# Altium schematic sheet Microcontroller.SchDoc
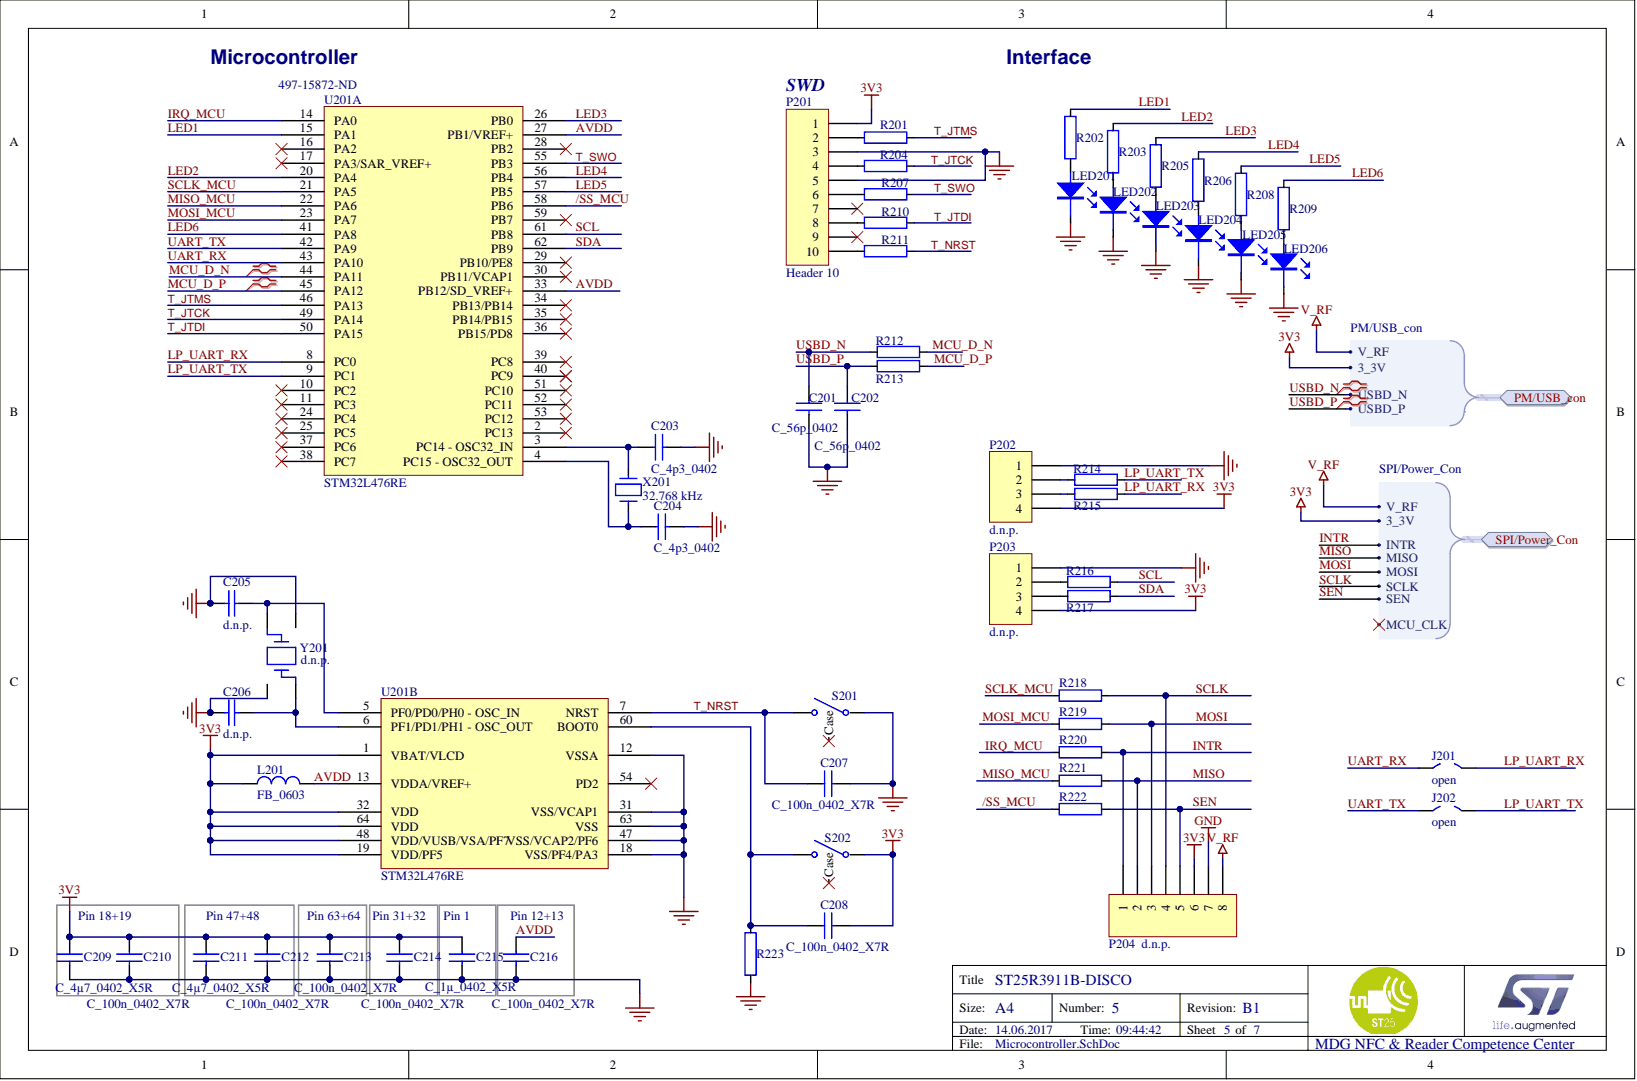
[diagram p0: Microcontroller.SchDoc]

## Parts
C201  C_56p_0402  [C0402]
C202  C_56p_0402  [C0402]
C203  C_4p3_0402  [C0402]
C204  C_4p3_0402  [C0402]
C205  d.n.p.  [C0603]
C206  d.n.p.  [C0603]
C207  C_100n_0402_X7R  [C0402]
C208  C_100n_0402_X7R  [C0402]
C209  C_4µ7_0402_X5R  [C0402]
C210  C_100n_0402_X7R  [C0402]
C211  C_4µ7_0402_X5R  [C0402]
C212  C_100n_0402_X7R  [C0402]
C213  C_100n_0402_X7R  [C0402]
C214  C_100n_0402_X7R  [C0402]
C215  C_1µ_0402_X5R  [C0402]
C216  C_100n_0402_X7R  [C0402]
J201  open  [JP2_SMD_open]
J202  open  [JP2_SMD_open]
L201  FB_0603  [L0603]
LED201  Blue  [D0603]  (value not drawn)
LED202  Blue  [D0603]  (value not drawn)
LED203  Blue  [D0603]  (value not drawn)
LED204  Blue  [D0603]  (value not drawn)
LED205  Blue  [D0603]  (value not drawn)
LED206  Blue  [D0603]  (value not drawn)
P201  Header 10  [Samtec-Strip5x2]
P202  d.n.p.  [HDR1X4]
P203  d.n.p.  [HDR1X4]
P204  d.n.p.  [HDR1X8]
R201  220R  [R0402]  (value not drawn)
R202  680R  [R0402]  (value not drawn)
R203  680R  [R0402]  (value not drawn)
R204  220R  [R0402]  (value not drawn)
R205  680R  [R0402]  (value not drawn)
R206  680R  [R0402]  (value not drawn)
R207  220R  [R0402]  (value not drawn)
R208  680R  [R0402]  (value not drawn)
R209  680R  [R0402]  (value not drawn)
R210  220R  [R0402]  (value not drawn)
R211  220R  [R0402]  (value not drawn)
R212  27R  [R0402]  (value not drawn)
R213  27R  [R0402]  (value not drawn)
R214  220R  [R0402]  (value not drawn)
R215  220R  [R0402]  (value not drawn)
R216  220R  [R0402]  (value not drawn)
R217  220R  [R0402]  (value not drawn)
R218  0R  [R0402]  (value not drawn)
R219  0R  [R0402]  (value not drawn)
R220  0R  [R0402]  (value not drawn)
R221  0R  [R0402]  (value not drawn)
R222  0R  [R0402]  (value not drawn)
R223  10k  [R0402]  (value not drawn)
S201  (no value)  [SW_SPST_KMR211G]
S202  (no value)  [SW_SPST_KMR211G]
U201  STM32L476RE  Supplier Part Number 1: 497-15872-ND  [LQFP64_slim]  (parts A,B)
X201  32.768 kHz  [NX3215SA]
Y201  d.n.p.  [XRCGB_F_MN]

## Ports
PM/USB_con, PM/USB_con.3_3V, PM/USB_con.USBD_N, PM/USB_con.USBD_P, PM/USB_con.V_RF, SPI/Power_Con, SPI/Power_Con.3_3V, SPI/Power_Con.INTR, SPI/Power_Con.MCU_CLK, SPI/Power_Con.MISO, SPI/Power_Con.MOSI, SPI/Power_Con.SCLK, SPI/Power_Con.SEN, SPI/Power_Con.V_RF

## Nets
GND: C201.2 C202.2 C203.2 C204.2 C205.2 C206.2 C207.2 C209.2 C210.2 C211.2 C212.2 C213.2 C214.2 C215.2 C216.2 LED201.2 LED202.2 LED203.2 LED204.2 LED205.2 LED206.2 P201.3 P201.5 P202.1 P203.1 P204.7 R223.1 S201.2 U201.12(VSSA) U201.18(VSS/PF4/PA3) U201.31(VSS/VCAP1) U201.47(VSS/VCAP2/PF6) U201.63(VSS) Y201.2 Y201.4
3V3: C208.2 C209.1 C210.1 C211.1 C212.1 C213.1 C214.1 C215.1 L201.2 P201.1 P202.4 P203.4 P204.6 S202.2 U201.1(VBAT/VLCD) U201.19(VDD/PF5) U201.32(VDD) U201.48(VDD/VUSB/VSA/PF7) U201.64(VDD) ; port PM/USB_con, port SPI/Power_Con
/SS_MCU: R222.2 U201.58(PB6)
AVDD: C216.1 L201.1 U201.13(VDDA/VREF+) U201.27(PB1/VREF+) U201.33(PB12/SD_VREF+)
INTR: P204.1 R220.1 ; port SPI/Power_Con
IRQ_MCU: R220.2 U201.14(PA0)
LED1: R202.2 U201.15(PA1)
LED2: R203.2 U201.20(PA4)
LED3: R205.2 U201.26(PB0)
LED4: R206.2 U201.56(PB4)
LED5: R208.2 U201.57(PB5)
LED6: R209.2 U201.41(PA8)
LP_UART_RX: J201.2 R215.1 U201.8(PC0)
LP_UART_TX: J202.2 R214.1 U201.9(PC1)
MCU_D_N: R212.1 U201.44(PA11)
MCU_D_P: R213.1 U201.45(PA12)
MISO: P204.2 R221.1 ; port SPI/Power_Con
MISO_MCU: R221.2 U201.22(PA6)
MOSI: P204.3 R219.1 ; port SPI/Power_Con
MOSI_MCU: R219.2 U201.23(PA7)
SCL: R216.1 U201.61(PB8)
SCLK: P204.4 R218.1 ; port SPI/Power_Con
SCLK_MCU: R218.2 U201.21(PA5)
SDA: R217.1 U201.62(PB9)
SEN: P204.5 R222.1 ; port SPI/Power_Con
T_JTCK: R204.1 U201.49(PA14)
T_JTDI: R210.1 U201.50(PA15)
T_JTMS: R201.1 U201.46(PA13)
T_NRST: C207.1 R211.1 S201.1 U201.7(NRST)
T_SWO: R207.1 U201.55(PB3)
UART_RX: J201.1 U201.43(PA10)
UART_TX: J202.1 U201.42(PA9)
USBD_N: C201.1 R212.2 ; port PM/USB_con
USBD_P: C202.1 R213.2 ; port PM/USB_con
V_RF: P204.8 ; port PM/USB_con, port SPI/Power_Con
NetC203_1: C203.1 U201.3(PC14_-_OSC32_IN) X201.2
NetC204_1: C204.1 U201.4 X201.1
NetC205_1: C205.1 U201.5 Y201.1
NetC206_1: C206.1 U201.6 Y201.3
NetC208_1: C208.1 R223.2 S202.1 U201.60(BOOT0)
NetLED201_1: LED201.1 R202.1
NetLED202_1: LED202.1 R203.1
NetLED203_1: LED203.1 R205.1
NetLED204_1: LED204.1 R206.1
NetLED205_1: LED205.1 R208.1
NetLED206_1: LED206.1 R209.1
NetP201_2: P201.2 R201.2
NetP201_4: P201.4 R204.2
NetP201_6: P201.6 R207.2
NetP201_8: P201.8 R210.2
NetP201_10: P201.10 R211.2
NetP202_2: P202.2 R214.2
NetP202_3: P202.3 R215.2
NetP203_2: P203.2 R216.2
NetP203_3: P203.3 R217.2
N00060: ; port SPI/Power_Con

Not connected: P201 7 9; S201 0; S202 0; U201 2(PC13) 10(PC2) 11(PC3) 16(PA2) 17(PA3/SAR_VREF+) 24(PC4) 25(PC5) 28(PB2) 29(PB10/PE8) 30(PB11/VCAP1) 34(PB13/PB14) 35(PB14/PB15) 36(PB15/PD8) 37(PC6) 38(PC7) 39(PC8) 40(PC9) 51(PC10) 52(PC11) 53(PC12) 54(PD2) 59(PB7)

## Library data of the parts
C201 C202 = C_56p_0402: 56p_COG; Manufacturer: MURATA; Manufacturer Part Number: GRM1555C1H560GA01D; 2nd Source: 56pF ±2% 50V  C0G, NP0 0402; Component Kind: Standard; Library Name: Schlib1.SchLib; Supplier 1: Digi-Key; Supplier Part Number 1: 490-6247-1-ND
C203 C204 = C_4p3_0402: 4p3_COG; Manufacturer: MURATA; Manufacturer Part Number: GRM0225C1E4R3BA03L; 2nd Source: 0402, 4.3PF 25V, C0G, 0.1pF; Component Kind: Standard; Library Name: Schlib1.SchLib; Supplier 1: Digi-Key; Supplier Part Number 1: 490-13573-1-ND
C205 C206 = CAP: Capacitor; Component Kind: Standard
C207 C208 C210 C212-C214 C216 = C_100n_0402_X7R: 100n_X7R; Manufacturer: MULTICOMP; Manufacturer Part Number: MC0402X104K100CT; 2nd Source: 0402, 0.1 µF, 10 V, ± 10%, X5R,; Component Kind: Standard; Library Name: Schlib1.SchLib; Supplier 1: Farnell; Supplier Part Number 1: 1759380
C209 C211 = C_4µ7_0402_X5R: 4u7_X5R; Manufacturer: TDK; Manufacturer Part Number: C1005X5R0J475K050BC; 2nd Source: 0402, 4.7 µF, 6.3 V, ± 10%, X5R; Component Kind: Standard; Library Name: Schlib1.SchLib; Supplier 2: Farnell; Supplier Part Number 2: 2346876
C215 = C_1µ_0402_X5R: 1u_X5R; Manufacturer: MURATA; Manufacturer Part Number: GRM155R61C105KA12D; 2nd Source: 0402, 1 µF, 16 V, ± 10%, X5R,; Component Kind: Standard; Library Name: Schlib1.SchLib; Supplier 1: Farnell; Supplier Part Number 1: 2362093
J201 J202 = solder_jumper_open: Component Kind: Net Tie; Library Name: Schlib1.SchLib
L201 = FB_0603: Manufacturer: MURATA; Manufacturer Part Number: BLM18EG471SN1D; Component Kind: Standard; Library Name: Schlib1.SchLib; Supplier 1: Farnell; Supplier Part Number 1: 1515722
LED201-LED206 = LED-0603-Blue: Manufacturer: Lite-On; Manufacturer Part Number: LTST-C190TBKT; Component Kind: Standard; Library Name: Schlib1.SchLib; Supplier 1: Digi-Key; Supplier Part Number 1: 160-1646-1-ND
P201 = Header 10: Samtec; Manufacturer Part Number: FTS-105-01-L-DV; Component Kind: Standard; Library Name: Schlib1.SchLib; Manufacturer: Samtec; Supplier 1: Farnell; Supplier Part Number 1: 1667729
P202 P203 = Header 4: Header, 4-Pin; Component Kind: Standard; LatestRevisionDate: 17-Jul-2002; LatestRevisionNote: Re-released for DXP Platform.; Library Name: Miscellaneous Connectors.IntLib; Signal Integrity: Connector; Publisher: Altium Limited
P204 = Header 8: Header, 8-Pin; Component Kind: Standard; LatestRevisionDate: 17-Jul-2002; LatestRevisionNote: Re-released for DXP Platform.; Library Name: Miscellaneous Connectors.IntLib; Signal Integrity: Connector; Publisher: Altium Limited
R201 R204 R207 R210 R211 R214-R217 = R0402_220R: 220R, 0402; Manufacturer: Yageo; Manufacturer Part Number: RC0402JR-07220RL; 2nd Source: 220 Ohm ±5% 0.063W, 1/16W Chip Resistor 0402; Component Kind: Standard; Library Name: Schlib1.SchLib; Supplier 1: Digi-Key; Supplier Part Number 1: 311-220JRTR-ND
R202 R203 R205 R206 R208 R209 = R0402_680R: 680R, 0402; Manufacturer: Yageo; Manufacturer Part Number: RC0402JR-07680RL; 2nd Source: 680 Ohm ±5% 0.063W, 1/16W Chip Resistor 0402; Component Kind: Standard; Library Name: Schlib1.SchLib; Supplier 1: Digi-Key; Supplier Part Number 1: 311-680JRTR-ND
R212 R213 = R0402_27R: 27R, 0402; Manufacturer: MULTICOMP; Manufacturer Part Number: MC00625W0402127R; 2nd Source: 27ohm, 50 V, 0402, >62.5 mW, ± 1%; Component Kind: Standard; Library Name: Schlib1.SchLib; Supplier 1: Farnell; Supplier Part Number 1: 1358000
R218-R222 = R0402_0R: 0R, 0402; Manufacturer: MULTICOMP; Manufacturer Part Number: MC00625W040210R; 2nd Source: 0 ohm, 50 V, 0402, >62.5 mW; Component Kind: Standard; Library Name: Schlib1.SchLib; Supplier 1: Farnell; Supplier Part Number 1: 1357983
R223 = R0402_10k: 10k, 0402; Manufacturer: MULTICOMP; Manufacturer Part Number: MC00625W0402110K; 2nd Source: 10 kohm, 50 V, 0402, >62.5 mW, ± 1%; Component Kind: Standard; Library Name: Schlib1.SchLib; Supplier 1: Farnell; Supplier Part Number 1: 1358069
S201 S202 = SW_SPST2_GND: Manufacturer: C & K COMPONENTS; Manufacturer Part Number: KMR211G LFS; Component Kind: Standard; ComponentLink1Description: http://www.farnell.com/datasheets/1697814.pdf; ComponentLink1URL: http://www.farnell.com/datasheets/1697814.pdf; Library Name: Mechanical_3D.IntLib; Pricing 1: 1=0,647, 50=0,557, 100=0,463, 7000=0,257 (EUR); RoHS: YES; Stock 1: 6014; Supplier 1: Farnell; Supplier Part Number 1: 1437635
U201 = MCU_LQFP64: ST or Subco proc: delivered by ST; Component Kind: Standard; Library Name: Nucleo_64.SCHLIB; Supplier 1: Digi-Key
X201 = NX3215SA-32.768K-STD-MUA-8: Crystal 32kHz; Manufacturer: NDK; Component Kind: Standard; Library Name: Schlib1.SchLib; Manufacturer Part Number: NX3215SA-32.768K-STD-MUA-8; Supplier 1: Digi-Key; Supplier Part Number 1: 644-1156-1-ND
Y201 = Crystal_XRCGB27M12: Manufacturer: MURATA; Manufacturer Part Number: XRCGB27M120F3M00R0; Component Kind: Standard; ComponentLink1Description: http://search.murata.co.jp/Ceramy/image/img/w_hinm/L0445E.pdf; ComponentLink1URL: http://search.murata.co.jp/Ceramy/image/img/w_hinm/L0445E.pdf; ComponentLink2Description: http://www.murata.com/products/catalog/pdf/p79e.pdf; ComponentLink2URL: http://www.murata.com/products/catalog/pdf/p79e.pdf; Library Name: AS3911 Eval V1.IntLib; RoHS: YES; Supplier 1: Digi-Key; Supplier Part Number 1: 490-5575-1-ND
